# Altium schematic sheet PI_CAN_Interface_v2.SchDoc
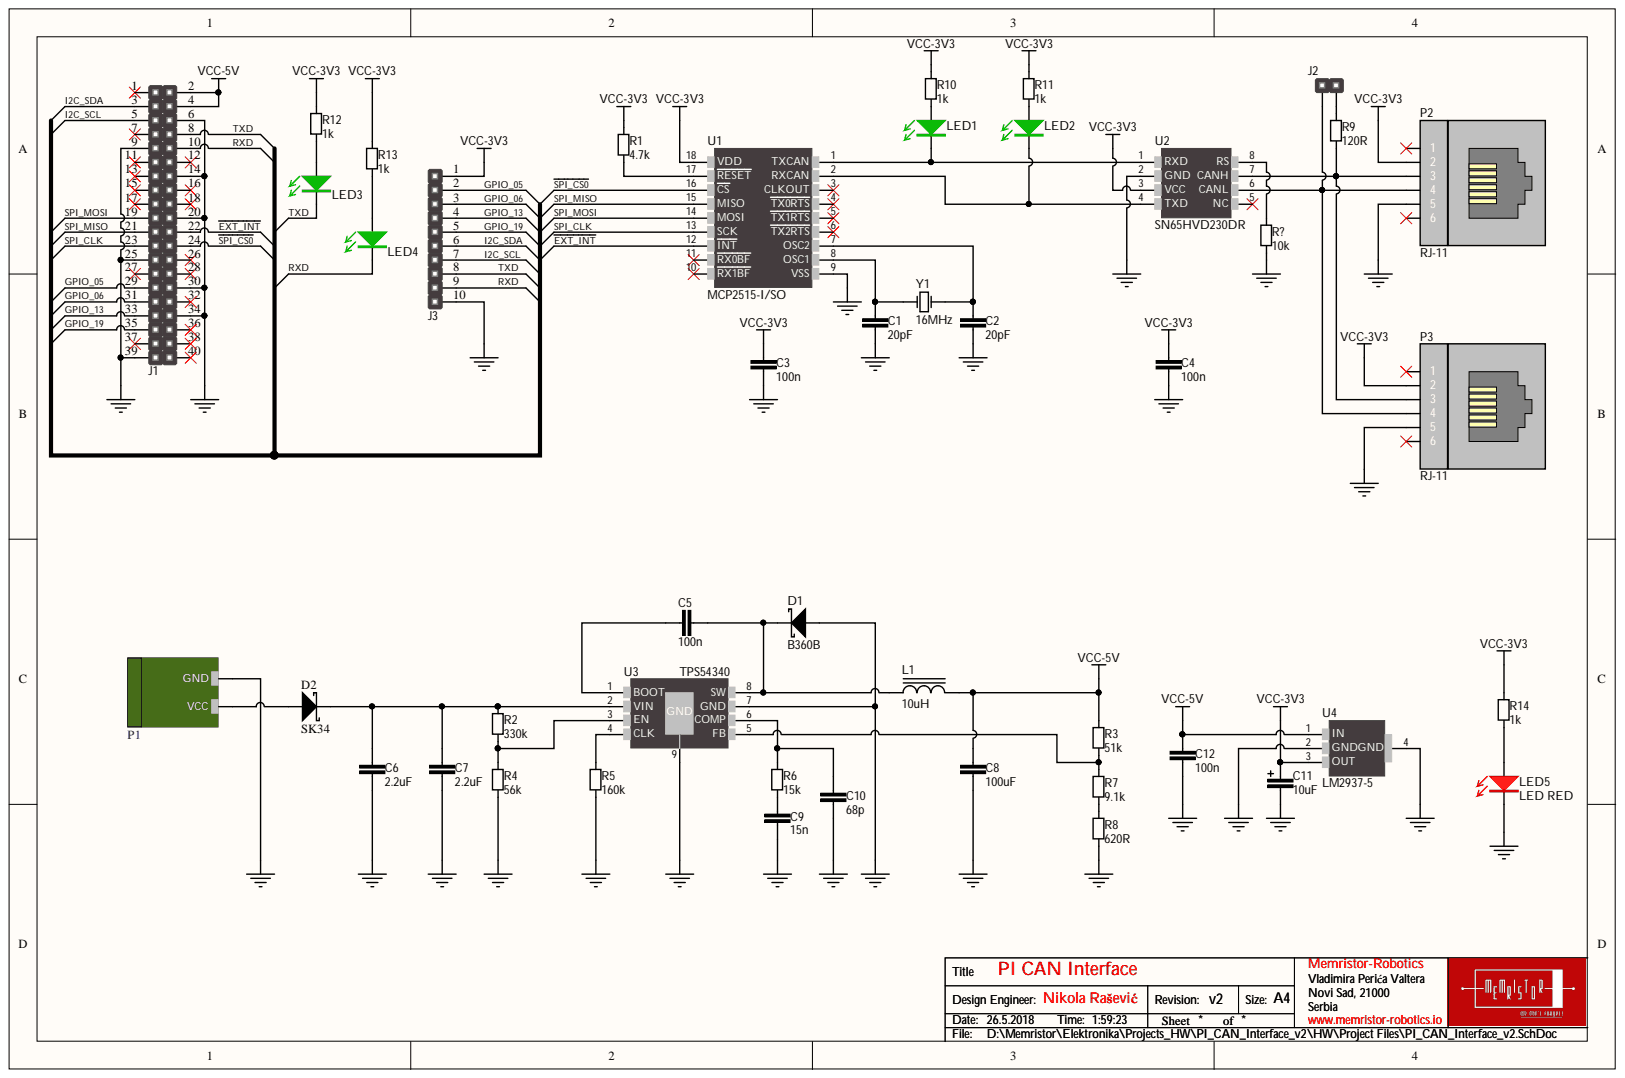
[diagram p0: PI_CAN_Interface_v2.SchDoc]

## Parts
C1  20pF  [CER_0603]
C2  20pF  [CER_0603]
C3  100n  [CER_0603]
C4  100n  [CER_0603]
C5  100n  [CER_0603]
C6  2.2uF  [CER_1210]
C7  2.2uF  [CER_1210]
C8  100uF  [CER_1210]
C9  15n  [CER_0603]
C10  68p  [CER_0603]
C11  10uF  [TANT_1210]
C12  100n  [CER_0603]
D1  B360B  [DO214AC]
D2  SK34  [DO214AC]
J1  00000005  [HM2X20]  (value not drawn)
J2  (no value)  [HM1x2]
J3  00000006  [HM1X10]  (value not drawn)
L1  10uH  [INDUCTOR_SS1260-103MLD]
LED1  LED GREEN  [LED_GREEN_0805]  (value not drawn)
LED2  LED GREEN  [LED_GREEN_0805]  (value not drawn)
LED3  LED GREEN  [LED_GREEN_0805]  (value not drawn)
LED4  LED GREEN  [LED_GREEN_0805]  (value not drawn)
LED5  LED RED  Family: LED  [LED_RED_0805]
P1  (no value)  [PWR_Con]
P2  RJ-11  [RJ11-6N-B]
P3  RJ-11  [RJ11-6N-B]
R1  4.7k  [RESC2013X38N-0805-NOOVERLAY]
R2  330k  [RESC2013X38N-0805-NOOVERLAY]
R3  51k  [RESC2013X38N-0805-NOOVERLAY]
R4  56k  [RESC2013X38N-0805-NOOVERLAY]
R5  160k  [RESC2013X38N-0805-NOOVERLAY]
R6  15k  [RESC2013X38N-0805-NOOVERLAY]
R7  9.1k  [RESC2013X38N-0805-NOOVERLAY]
R8  620R  [RESC2013X38N-0805-NOOVERLAY]
R9  120R  [RESC2013X38N-0805-NOOVERLAY]
R10  1k  [RESC2013X38N-0805-NOOVERLAY]
R11  1k  [RESC2013X38N-0805-NOOVERLAY]
R12  1k  [RESC2013X38N-0805-NOOVERLAY]
R13  1k  [RESC2013X38N-0805-NOOVERLAY]
R14  1k  [RESC2013X38N-0805-NOOVERLAY]
R?  10k  [RESC2013X38N-0805-NOOVERLAY]
U1  MCP2515-I/SO  [SOIC-18]
U2  SN65HVD230DR  [SOIC-8]
U3  TPS54340  [HSOP-8]
U4  LM2937-5  [SOT223]
Y1  16MHz  [OSCILATOR]

## Nets
GND: C1.1 C2.1 C3.1 C4.1 C6.1 C7.1 C8.1 C9.1 C10.1 C11.2 C12.1 D1.2 J1.6 J1.9 J1.14 J1.20 J1.25 J1.30 J1.34 J1.39 J3.10 LED5.1 P1.1 P2.5 P3.5 R4.1 R5.1 R8.1 R?.1 U1.9(VSS) U2.2(GND) U3.7(GND) U3.9 U4.2(GND) U4.4(GND)
VCC-3V3: C3.2 C4.2 C11.1 J3.1 P2.2 P3.2 R1.2 R10.2 R11.2 R12.2 R13.2 R14.2 U1.18(VDD) U2.3(VCC) U4.3(OUT)
VCC-5V: C8.2 C12.2 J1.2 J1.4 L1.2 R3.2 U4.1(IN)
~{EXT_INT}: J1.22 U1.12(INT)
GPIO_05: J1.29 J3.2
GPIO_06: J1.31 J3.3
GPIO_13: J1.33 J3.4
GPIO_19: J1.35 J3.5
I2C_SCL: J1.5 J3.7
I2C_SDA: J1.3 J3.6
RXD: J1.10 J3.9 LED4.1
~{SPI_CS0}: J1.24 U1.16(CS)
SPI_CLK: J1.23 U1.13(SCK)
SPI_MISO: J1.21 U1.15(MISO)
SPI_MOSI: J1.19 U1.14(MOSI)
TXD: J1.8 J3.8 LED3.1
NetC1_2: C1.2 U1.8(OSC1) Y1.2
NetC2_2: C2.2 U1.7(OSC2) Y1.1
NetC5_1: C5.1 U3.1(BOOT)
NetC5_2: C5.2 D1.1 L1.1 U3.8(SW)
NetC6_2: C6.2 C7.2 D2.1 R2.2 U3.2(VIN)
NetC9_2: C9.2 R6.1
NetC10_2: C10.2 R6.2 U3.6(COMP)
NetD2_2: D2.2 P1.2
NetJ2_1: J2.1 P2.4 P3.4 U2.6(CANL)
NetJ2_2: J2.2 R9.2
NetLED1_1: LED1.1 U1.1(TXCAN) U2.1(RXD)
NetLED1_2: LED1.2 R10.1
NetLED2_1: LED2.1 U1.2(RXCAN) U2.4(TXD)
NetLED2_2: LED2.2 R11.1
NetLED3_2: LED3.2 R12.1
NetLED4_2: LED4.2 R13.1
NetLED5_2: LED5.2 R14.1
NetP2_3: P2.3 P3.3 R9.1 U2.7(CANH)
NetR1_1: R1.1 U1.17(RESET)
NetR2_1: R2.1 R4.2 U3.3(EN)
NetR3_1: R3.1 R7.2 U3.5(FB)
NetR5_2: R5.2 U3.4(CLK)
NetR7_1: R7.1 R8.2
NetR?_2: R?.2 U2.8(RS)
N00009:

Not connected: J1 1 7 11 12 13 15 16 17 18 26 27 28 32 36 37 38 40; P2 1 6; P3 1 6; U1 3(CLKOUT) 4(TX0RTS) 5(TX1RTS) 6(TX2RTS) 10(RX1BF) 11(RX0BF); U2 5(NC)

## Library data of the parts
C1 C2 = 00002200: CAP CER 20p 0805; Capacitance: 20pF; Component Kind: Standard; Library Name: Capacitors.IntLib
C3-C5 C12 = 06032105: CAP CER 100n 10V X5R 10% 0603; Capacitance: 100n; Component Kind: Standard; Library Name: Capacitors.IntLib
C6 C7 = 00002225: CAP CER 2.2u X7R 100V 20% 1210; Capacitance: 2.2uF; Component Kind: Standard; Library Name: Capacitors.IntLib
C8 = 00002108: CAP CER 100u X5R 6.3V 10% 1210; Capacitance: 100uF; Component Kind: Standard; Library Name: Capacitors.IntLib
C9 = 06032153: CAP CER 15n 0603; Capacitance: 15n; Component Kind: Standard; Library Name: Capacitors.IntLib
C10 = 06032680: CAP CER 68p 0603; Capacitance: 68p; Component Kind: Standard; Library Name: Capacitors.IntLib
C11 = 00001106: CAP TANT 10u; Component Kind: Standard; Library Name: Capacitors.SchLib; Value: 10uF
D1 = B360B: DIODE SCHOTTKY 60V 3A DO214AC; Manufacturer: Vishay Semiconductor Diodes Division; Assembly: SMD; Barcode: 0100000007488; id: 62; item_id: 1025; Recommended Rating: Silver; Speed: Fast Recovery =< 500ns, > 200mA (Io); Voltage  Forward: 550mV @ 3A; Category: Discrete Semiconductor Products; Component Kind: Standard; Current  Average Rectified: 3A; Datasheet URL: http://www.vishay.com/docs/88896/b330la.pdf; Diode Type: Schottky; Family: DIODE; Library Name: Discrete_Semiconductor.IntLib; Manufacturer Part Number: B360B; Package Case: DO-214AC, SMA; Part Type: Discrete Semiconductors; Voltage  DC Reverse: 60V
D2 = SK34: DIODE SCHOTTKY 40V 3A DO214AC; Manufacturer: Vishay Semiconductor Diodes Division; Assembly: SMD; Barcode: 0100000007488; id: 62; item_id: 1025; Recommended Rating: Silver; Speed: Fast Recovery =< 500ns, > 200mA (Io); Voltage  Forward: 550mV @ 3A; Category: Discrete Semiconductor Products; Component Kind: Standard; Current  Average Rectified: 3A; Datasheet URL: http://www.vishay.com/docs/88896/b330la.pdf; Diode Type: Schottky; Family: DIODE; Library Name: Discrete_Semiconductor.IntLib; Manufacturer Part Number: SK34; Package Case: DO-214AC, SMA; Part Type: Discrete Semiconductors; Voltage  DC Reverse: 40V
J2 = 00000003: Component Kind: Standard; Library Name: Electro_Mechanical.IntLib
L1 = IND_10uH: FIXED IND 10uH 3A; Manufacturer: Coilcraft; Manufacturer Part Number: XAL7030-103MEB; Assembly: SMD; DC Resistance: 45mOhm; Tolerance: 20%; Category: Inductors, Coils, Chokes; Component Kind: Standard; Current Rating: 3A; Datasheet URL: http://www.mouser.com/ds/2/597/MSS7348-1076571.pdf; Family: INDUCTOR; Inductance: 10uH; Library Name: Discrete_Semiconductor.IntLib; Package Case: 8x8mm; Part Type: Inductor, Transf, Filter, Ferrite; Product Page Mouser: https://eu.mouser.com/ProductDetail/Coilcraft/MSS7348-103MEB/?qs=sGAEpiMZZMsg%252by3WlYCkU%252bbmGPYv72aIHZue6QQJkp0%3d
LED1-LED4 = LED_GREEN 0805: LED GREEN CLEAR 0805 SMD; Manufacturer: Kingbright; Manufacturer Part Number: APT2012SGC; Barcode: 2911010024338; id: 28; item_id: 3342; Lens Color: Colorless; Recommended Rating: Platinum; Voltage Forward: 2.2V; Wavelength: 568nm; Assembly: SMD; Category: Optoelectronics; Color: Green; Component Kind: Standard; Datasheet URL: http://www.kingbrightusa.com/images/catalog/SPEC/APT2012SGC.pdf; Family: LED; Lens Transparency: Clear; Library Name: Discrete_Semiconductor.IntLib; Package Case: 0805 (2012 Metric); Part Type: Optoelectronics
LED5 = LED_RED_0805: LED RED 0805; Manufacturer: BRIGHT LED ELECTRONICS; Manufacturer Part Number: BL-HUB35A-AV-TRB; Assembly: SMD; Barcode: 2911010024321; id: 35; item_id: 3341; Recommended Rating: Platinum; Voltage Forward: 2.0 V; Color: Red; Component Kind: Standard; Library Name: Discrete_Semiconductor.IntLib; Package Case: 0805 (2012 Metric); Part Type: Optoelectronics
P1 = 00000002: Component Kind: Standard; Library Name: Electro_Mechanical.IntLib
P2 P3 = 00000001: Component Kind: Standard; Library Name: Electro_Mechanical.IntLib; Manufacturer Part Number: RJ-11
R1 = 00004701: RES SMD 4.7 kOhm 1/8W 0805; Power: 0.125W, 1/8W; Assembly: SMD; Barcode: 00004701; Component Kind: Standard; Library Name: Resistors.SchLib; Resistance: 4.7k
R2 = 00003303: RES SMD 330 kOhm 1/8W 0805; Power: 0.125W, 1/8W; Assembly: SMD; Barcode: 00003303; Component Kind: Standard; Library Name: Resistors.SchLib; Resistance: 330k
R3 = 00005102: RES SMD 51 kOhm 1/8W 0805; Power: 0.125W, 1/8W; Assembly: SMD; Barcode: 00005102; Component Kind: Standard; Library Name: Resistors.IntLib; Resistance: 51k
R4 = 00005602: RES SMD 56 kOhm 1/8W 0805; Barcode: 00004701; Power: 0.125W, 1/8W; Assembly: SMD; Component Kind: Standard; Library Name: Resistors.SchLib; Resistance: 56k
R5 = 00001603: RES SMD 160 kOhm 1/8W 0805; Power: 0.125W, 1/8W; Assembly: SMD; Barcode: 00001603; Component Kind: Standard; Library Name: Resistors.SchLib; Resistance: 160k
R6 = 00001502: RES SMD 15 kOhm 1/8W 0805; Power: 0.125W, 1/8W; Assembly: SMD; Barcode: 00001502; Component Kind: Standard; Library Name: Resistors.IntLib; Resistance: 15k
R7 = 00009101: RES SMD 9.1 kOhm 1/8W 0805; Power: 0.125W, 1/8W; Assembly: SMD; Barcode: 00009101; Component Kind: Standard; Library Name: Resistors.IntLib; Resistance: 9.1k
R8 = 00006200: RES SMD 620 Ohm 1/8W 0805; Power: 0.125W, 1/8W; Assembly: SMD; Barcode: 00006200; Component Kind: Standard; Library Name: Resistors.IntLib; Resistance: 620R
R9 = 000001200: RES SMD 120 Ohm 1/8W 0805; Component Kind: Standard; Library Name: Resistors.SchLib; Resistance: 120R
R10-R14 = 00001001: RES SMD 1 kOhm 1/8W 0805; Barcode: 00009101; Power: 0.125W, 1/8W; Assembly: SMD; Component Kind: Standard; Library Name: Resistors.SchLib; Resistance: 1k
R? = 000001002: RES SMD 10 kOhm 1/8W 0805; Component Kind: Standard; Library Name: Resistors.IntLib; Resistance: 10k
U1 = MCP2515: IC CAN CONTROLLER W/SPI 18SOIC; Assembly: SMD; Barcode: 00000002; Category: Integrated Circuits (ICs); Comet Product Page: http://store.comet.rs/Catalogue/Product/6292/; Component Kind: Standard; Datasheet Link: http://ww1.microchip.com/downloads/en/DeviceDoc/21801d.pdf; Library Name: Integrated_circuits.IntLib; Manufacturer Part Number: MCP2515-I/SO; Package: SOIC-18; Subcategory: Comunication
U2 = SN65HVD230: IC EMC CAN TRANSCEIVER 8-SOIC; Assembly: SMD; Barcode: 00000001; Category: Integrated Circuits (ICs); Comet Product Page: http://store.comet.rs/Catalogue/Product/9057/; Component Kind: Standard; Datasheet Link: http://www.ti.com/lit/ds/symlink/sn65hvd232.pdf; Family: Comunication; Library Name: Integrated_circuits.IntLib; Manufacturer Part Number: SN65HVD230DR; Package: SOIC-8
U3 = 00000003: DC-DC Buck Regulator; Assembly: SMD; Component Kind: Standard; Library Name: Integrated_circuits.IntLib; Manufacturer Part Number: TPS54340
U4 = 00000007: IC REG LINEAR 5V 400MA SOT223-4; Component Kind: Standard; Datasheet Link: http://www.ti.com/lit/ds/symlink/lm2937.pdf; Library Name: Integrated_circuits.SchLib; Manufacturer Part Number: LM2937-5
Y1 = XtalSmd: Crystal Oscillator; Order Code: Q16.000 MHz HC-49SM SMD; Component Kind: Standard; LatestRevisionDate: 17-Jul-2002; LatestRevisionNote: Re-released for DXP Platform.; Library Name: Discrete_Semiconductor.IntLib; PackageReference: R38; Published: 8-Jun-2000; Supplier: Comet.rs; Value: 16MHz; Publisher: Altium Limited
J1 = 00000005: Component Kind: Standard; Library Name: Electro_Mechanical.IntLib
J3 = 00000006: Component Kind: Standard; Library Name: Electro_Mechanical.IntLib
